# Altium schematic sheet Completo.SchDoc
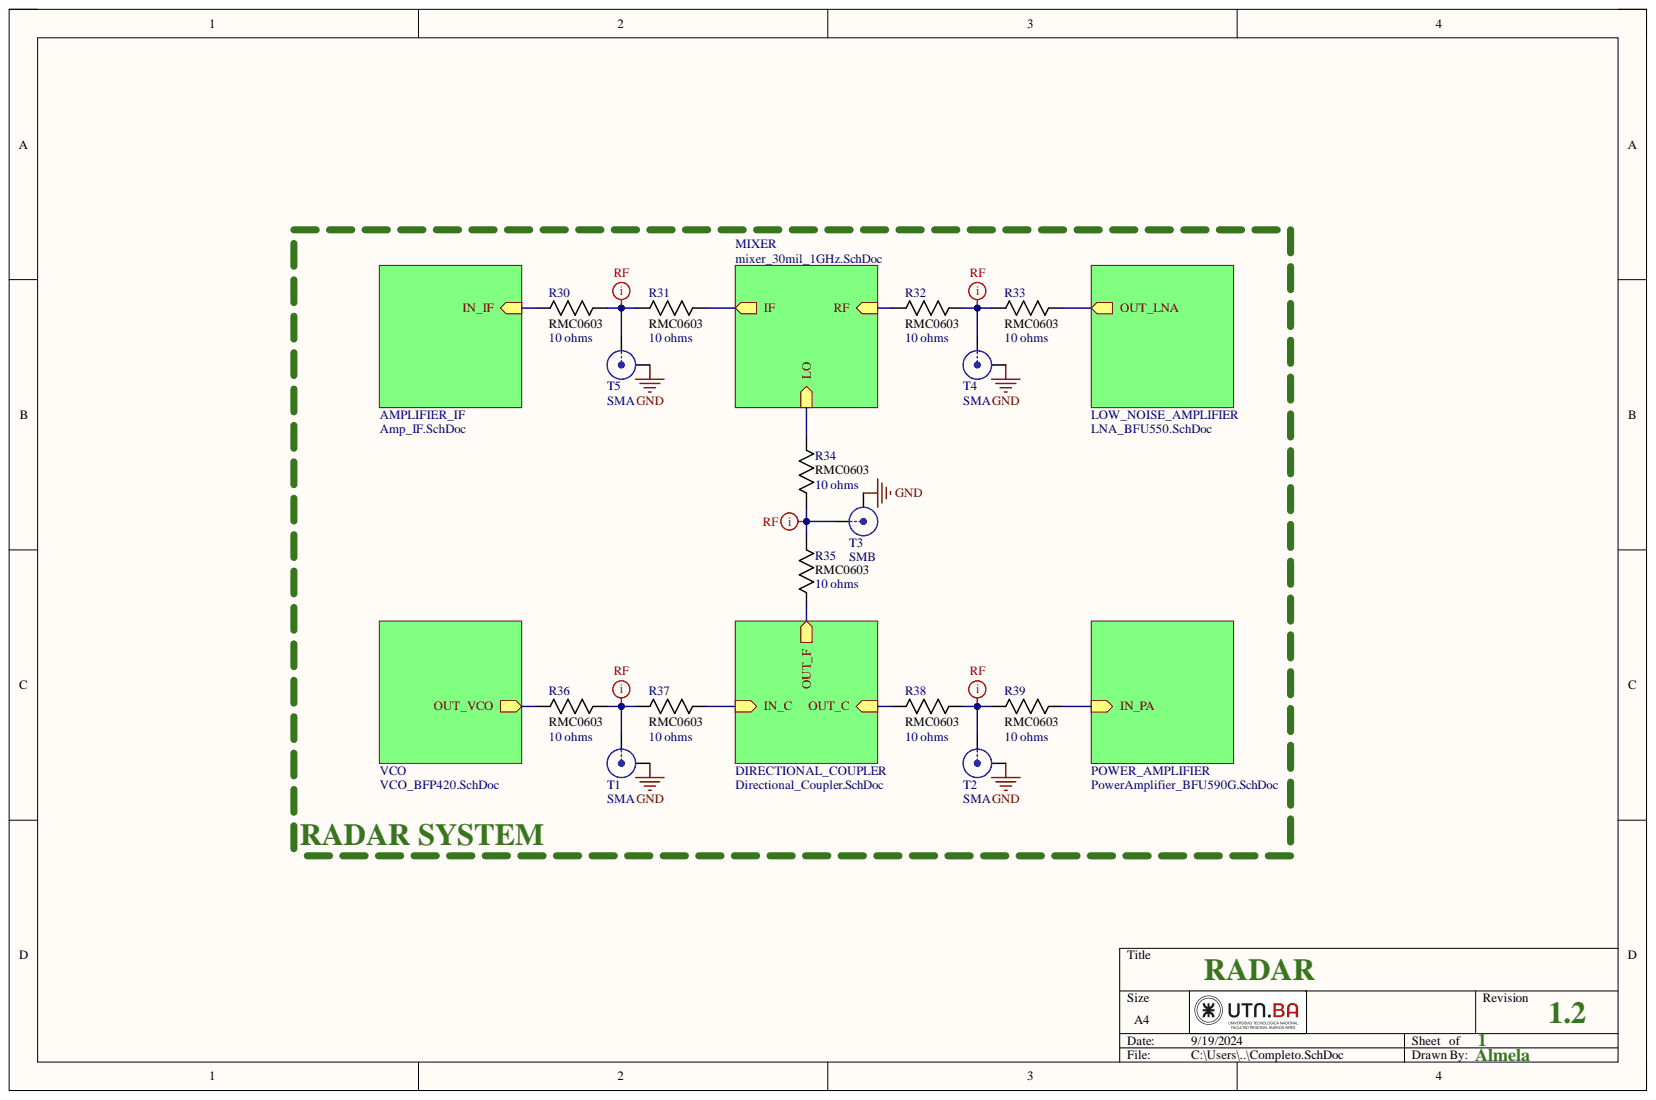
[diagram p0: Completo.SchDoc]

## Parts
R30  value 10 ohms  [RMC0603]  (hidden comment: Resistor)
R31  value 10 ohms  [RMC0603]  (hidden comment: Resistor)
R32  value 10 ohms  [RMC0603]  (hidden comment: Resistor)
R33  value 10 ohms  [RMC0603]  (hidden comment: Resistor)
R34  value 10 ohms  [RMC0603]  (hidden comment: Resistor)
R35  value 10 ohms  [RMC0603]  (hidden comment: Resistor)
R36  value 10 ohms  [RMC0603]  (hidden comment: Resistor)
R37  value 10 ohms  [RMC0603]  (hidden comment: Resistor)
R38  value 10 ohms  [RMC0603]  (hidden comment: Resistor)
R39  value 10 ohms  [RMC0603]  (hidden comment: Resistor)
T1  SMA  [SMA-142-0701-851]
T2  SMA  [SMA-142-0701-851]
T3  SMB  [SMB_V-RJ45]
T4  SMA  [SMA-142-0701-851]
T5  SMA  [SMA-142-0701-851]

## Nets
GND: T1.2 T2.2 T3.2 T4.2 T5.2
NetR30_1: R30.1
NetR30_2: R30.2 R31.1 T5.1
NetR31_2: R31.2
NetR32_1: R32.1
NetR32_2: R32.2 R33.1 T4.1
NetR33_2: R33.2
NetR34_1: R34.1 R35.2 T3.1
NetR34_2: R34.2
NetR35_1: R35.1
NetR36_1: R36.1
NetR36_2: R36.2 R37.1 T1.1
NetR37_2: R37.2
NetR38_1: R38.1
NetR38_2: R38.2 R39.1 T2.1
NetR39_2: R39.2

## Library data of the parts
R30 R32 R35 R36 R38 = Resistor: Resistor RMC0603; Mfr_Name: Stackpole International; Component Kind: Standard; Library Name: Radar_RF_EAIII.IntLib
R31 R33 R34 R37 R39 = Resistor: Resistor RMC0603; Mfr_Name: Stackpole International; value: 10 ohms; Component Kind: Standard; Library Name: Radar_RF_EAIII.IntLib
T1-T5 = SMB: SMB Straight Connector; Component Kind: Standard; LatestRevisionDate: 17-Jul-2002; LatestRevisionNote: Re-released for DXP Platform.; Signal Integrity: Connector; Publisher: Altium Limited
  T1 T2 T4 T5: Library Name: PCB_Project.SCHLIB
  T3: Library Name: Radar_RF_EAIII.IntLib
